# Altium schematic sheet FrontEnd.SchDoc
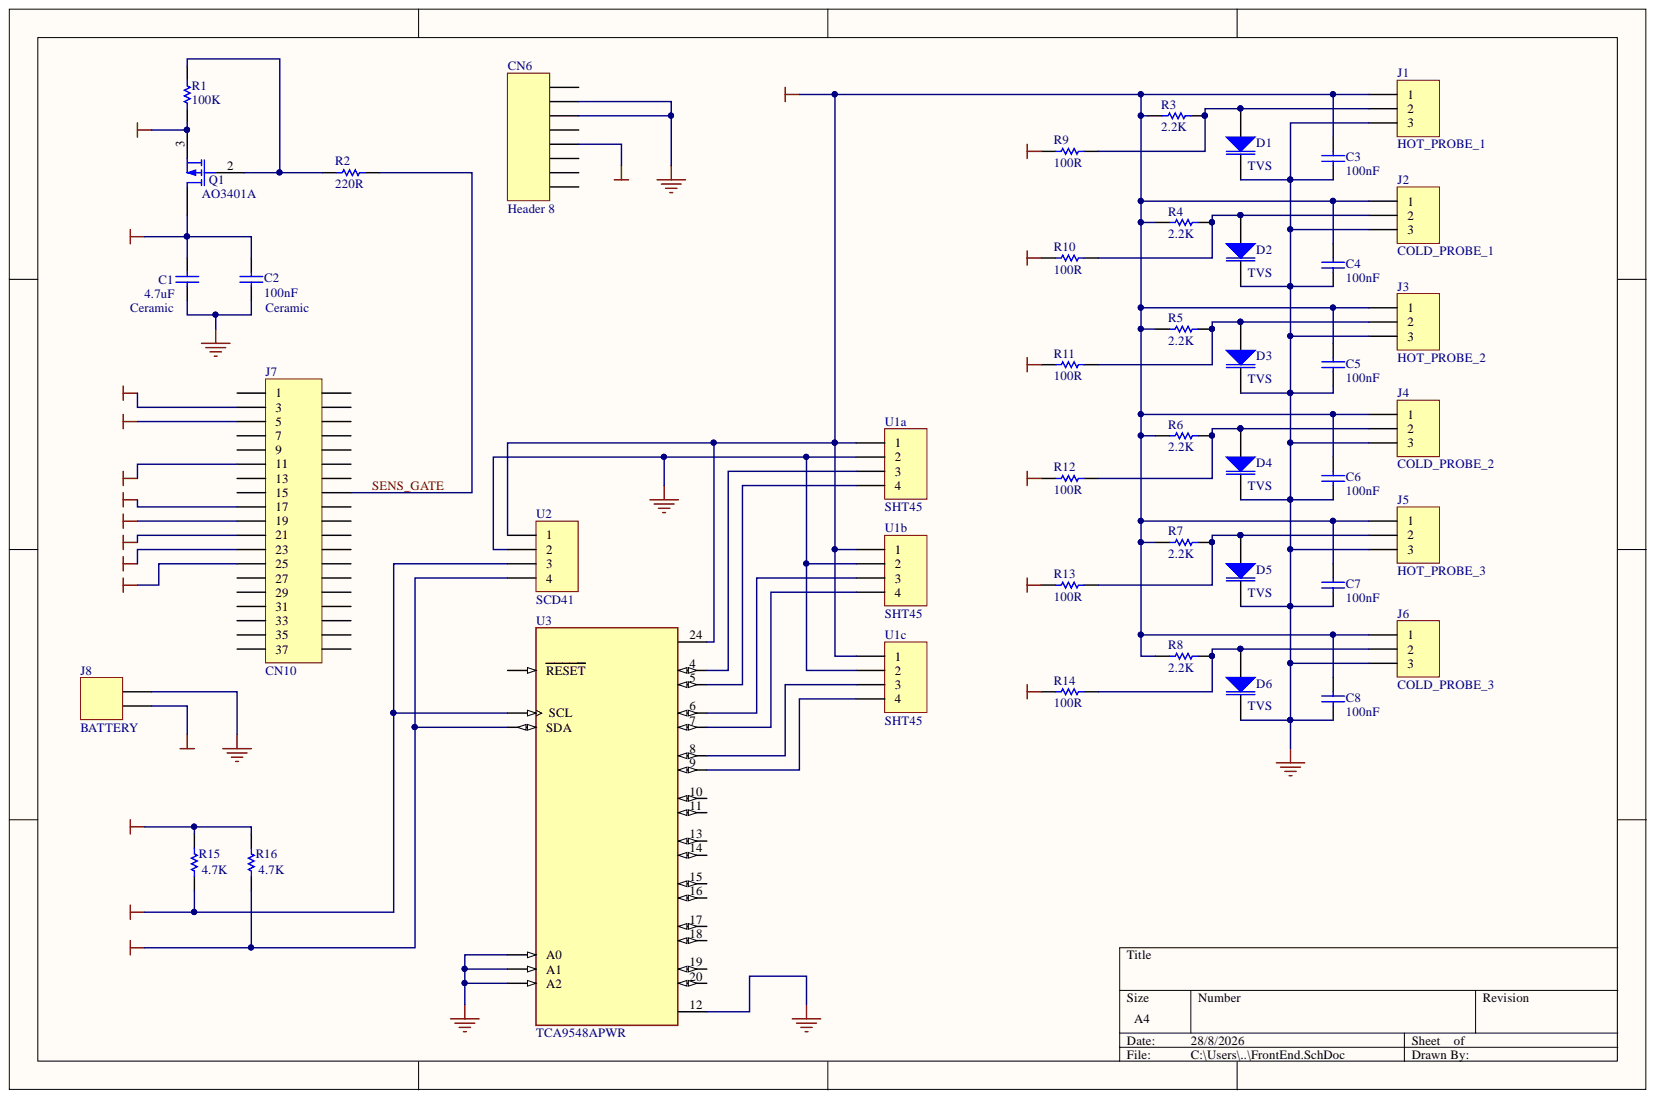
[diagram p0: FrontEnd.SchDoc]

## Parts
C1  Ceramic  value 4.7uF  [RAD-0.3]
C2  Ceramic  value 100nF  [RAD-0.3]
C3  100nF  [RAD-0.3]
C4  100nF  [RAD-0.3]
C5  100nF  [RAD-0.3]
C6  100nF  [RAD-0.3]
C7  100nF  [RAD-0.3]
C8  100nF  [RAD-0.3]
CN6  Header 8  [HDR1X8]
D1  TVS  [SOT23_N]
D2  TVS  [SOT23_N]
D3  TVS  [SOT23_N]
D4  TVS  [SOT23_N]
D5  TVS  [SOT23_N]
D6  TVS  [SOT23_N]
J1  HOT_PROBE_1  [HDR1X3]
J2  COLD_PROBE_1  [HDR1X3]
J3  HOT_PROBE_2  [HDR1X3]
J4  COLD_PROBE_2  [HDR1X3]
J5  HOT_PROBE_3  [HDR1X3]
J6  COLD_PROBE_3  [HDR1X3]
J7  CN10  [HDR2X19]
J8  BATTERY  [HDR1X2]
Q1  AO3401A  [E3]
R1  100K  [AXIAL-0.3]
R2  220R  [AXIAL-0.3]
R3  value 2.2K  [AXIAL-0.3]  (hidden comment: pullup)
R4  value 2.2K  [AXIAL-0.3]  (hidden comment: pullup)
R5  value 2.2K  [AXIAL-0.3]  (hidden comment: pullup)
R6  value 2.2K  [AXIAL-0.3]  (hidden comment: pullup)
R7  value 2.2K  [AXIAL-0.3]  (hidden comment: pullup)
R8  value 2.2K  [AXIAL-0.3]  (hidden comment: pullup)
R9  100R  [AXIAL-0.3]
R10  100R  [AXIAL-0.3]
R11  100R  [AXIAL-0.3]
R12  100R  [AXIAL-0.3]
R13  100R  [AXIAL-0.3]
R14  100R  [AXIAL-0.3]
R15  value 4.7K  [AXIAL-0.3]  (hidden comment: pullup)
R16  value 4.7K  [AXIAL-0.3]  (hidden comment: pullup)
U1a  SHT45  [HDR1X4]
U1b  SHT45  [HDR1X4]
U1c  SHT45  [HDR1X4]
U2  SCD41  [HDR1X4]
U3  TCA9548APWR  [SOP65P640X120-24N]

## Nets
DQ_P0: J7.11 R9.1
DQ_P1: J7.17 R10.1
DQ_P2: J7.19 R11.1
DQ_P3: J7.21 R12.1
DQ_P4: J7.23 R13.1
DQ_P5: J7.25 R14.1
GND: C1.2 C2.2 C3.2 C4.2 C5.2 C6.2 C7.2 C8.2 CN6.6 CN6.7 D1.1 D2.1 D3.1 D4.1 D5.1 D6.1 J1.3 J2.3 J3.3 J4.3 J5.3 J6.3 J8.2 U1a.2 U1b.2 U1c.2 U2.2 U3.1(A0) U3.2(A1) U3.12 U3.21(A2)
I2C_SCL: J7.3 R15.1 U2.3 U3.22(SCL)
I2C_SDA: J7.5 R16.1 U2.4 U3.23(SDA)
VBAT: CN6.4 J8.1 Q1.3 R1.2
VSENS: C1.1 C2.1 C3.1 C4.1 C5.1 C6.1 C7.1 C8.1 J1.1 J2.1 J3.1 J4.1 J5.1 J6.1 Q1.1 R3.2 R4.2 R5.2 R6.2 R7.2 R8.2 R15.2 R16.2 U1a.1 U1b.1 U1c.1 U2.1 U3.24
SENS_GATE: J7.16 R2.1
NetD1_3: D1.3 J1.2 R3.1 R9.2
NetD2_3: D2.3 J2.2 R4.1 R10.2
NetD3_3: D3.3 J3.2 R5.1 R11.2
NetD4_3: D4.3 J4.2 R6.1 R12.2
NetD5_3: D5.3 J5.2 R7.1 R13.2
NetD6_3: D6.3 J6.2 R8.1 R14.2
NetQ1_2: Q1.2 R1.1 R2.2
NetU1a_3: U1a.3 U3.4
NetU1a_4: U1a.4 U3.5
NetU1b_3: U1b.3 U3.6
NetU1b_4: U1b.4 U3.7
NetU1c_3: U1c.3 U3.8
NetU1c_4: U1c.4 U3.9

Not connected: CN6 1 2 3 5 8; J7 1 2 4 6 7 8 9 10 12 13 14 15 18 20 22 24 26 27 28 29 30 31 32 33 34 35 36 37 38; U3 3(RESET) 10 11 13 14 15 16 17 18 19 20

## Library data of the parts
C1-C8 = Cap: Capacitor; Component Kind: Standard; LatestRevisionDate: 17-Jul-2002; LatestRevisionNote: Re-released for DXP Platform.; Library Name: Miscellaneous Devices.IntLib; PackageDescription: Radial Cap, Thru-Hole; 2 Leads; 0.3 in Pin Spacing; PackageReference: RAD-0.3; Published: 8-Jun-2000; Signal Integrity: Cap; Simulation: CAP; Publisher: Altium Limited
  C3-C8: Value: 100nF
CN6 = Header 8: Header, 8-Pin; Component Kind: Standard; LatestRevisionDate: 17-Jul-2002; LatestRevisionNote: Re-released for DXP Platform.; Library Name: Miscellaneous Connectors.IntLib; Signal Integrity: Connector; Publisher: Altium Limited
D1-D6 = Diode BBY40: SOT23 Silicon Planar Variable Capacitance Diode; Note: Pin 2 is not connected and not drawn.; Component Kind: Standard; ComponentLink1Description: Manufacturer Link; ComponentLink1URL: http://www.zetex.com/; ComponentLink2Description: Datasheet; ComponentLink2URL: www.zetex.com/3.0/pdf/BBY40.pdf; DatasheetVersion: Jan-1998; LatestRevisionDate: 29-May-2009; LatestRevisionNote: IPC-7351 Footprint Added.; Library Name: Miscellaneous Devices.IntLib; PackageDescription: 3-Pin SOT-23 Package 0.95 mm Pitch, 2.3 mm Lead Span - Reverse Pinning; PackageReference: SOT23; PackageVersion: 1996; Published: 8-Jun-2000; Simulation: BBY40; Publisher: Altium Limited
J1-J6 = Header 3: Header, 3-Pin; Component Kind: Standard; LatestRevisionDate: 17-Jul-2002; LatestRevisionNote: Re-released for DXP Platform.; Library Name: Miscellaneous Connectors.IntLib; Signal Integrity: Connector; Publisher: Altium Limited
J7 = Header 19X2: Header, 19-Pin, Dual row; Component Kind: Standard; LatestRevisionDate: 17-Jul-2002; LatestRevisionNote: Re-released for DXP Platform.; Library Name: Miscellaneous Connectors.IntLib; Signal Integrity: Connector; Publisher: Altium Limited
J8 = Header 2: Header, 2-Pin; Component Kind: Standard; LatestRevisionDate: 17-Jul-2002; LatestRevisionNote: Re-released for DXP Platform.; Library Name: Miscellaneous Connectors.IntLib; Signal Integrity: Connector; Publisher: Altium Limited
Q1 = MOSFET-P: P-Channel MOSFET; Component Kind: Standard; LatestRevisionDate: 17-Jul-2002; LatestRevisionNote: Re-released for DXP Platform.; Library Name: Miscellaneous Devices.IntLib; PackageDescription: TO, Flat Index; 3 In-Line, Axial Leads; Body Dia. 4.6mm; Lead Dia. 0.5 mm (max); PackageReference: E3; PackageVersion: 1995/1996; Published: 8-Jun-2000; Simulation: PMOS; Publisher: Altium Limited
R1-R16 = Res1: Resistor; Component Kind: Standard; LatestRevisionDate: 17-Jul-2002; LatestRevisionNote: Re-released for DXP Platform.; Library Name: Miscellaneous Devices.IntLib; PackageDescription: Axial Device, Thru-Hole; 2 Leads; 0.3 in Pin Spacing; PackageReference: AXIAL-0.3; Published: 8-Jun-2000; Signal Integrity: Res; Simulation: RESISTOR; Publisher: Altium Limited
  R1: Value: 100K
  R2: Value: 220R
  R9-R14: Value: 100R
U1a U1b U1c U2 = Header 4: Header, 4-Pin; Component Kind: Standard; LatestRevisionDate: 17-Jul-2002; LatestRevisionNote: Re-released for DXP Platform.; Library Name: Miscellaneous Connectors.IntLib; Signal Integrity: Connector; Publisher: Altium Limited
U3 = TCA9548APWR: 8-channel 1.65- to 5.5-V I2C/SMBus switch with reset & voltage translation; MF: Texas Instruments; Check_prices: https://www.snapeda.com/parts/TCA9548APWR/Texas+Instruments/view-part/?ref=eda; Component Kind: Standard; Library Name: TCA9548APWR.IntLib; MP: TCA9548APWR; Package: TSSOP-24 Texas Instruments; SnapEDA_Link: https://www.snapeda.com/parts/TCA9548APWR/Texas+Instruments/view-part/?ref=snap
